# Altium schematic sheet BBB_Connections.SchDoc(BBB_Connections)
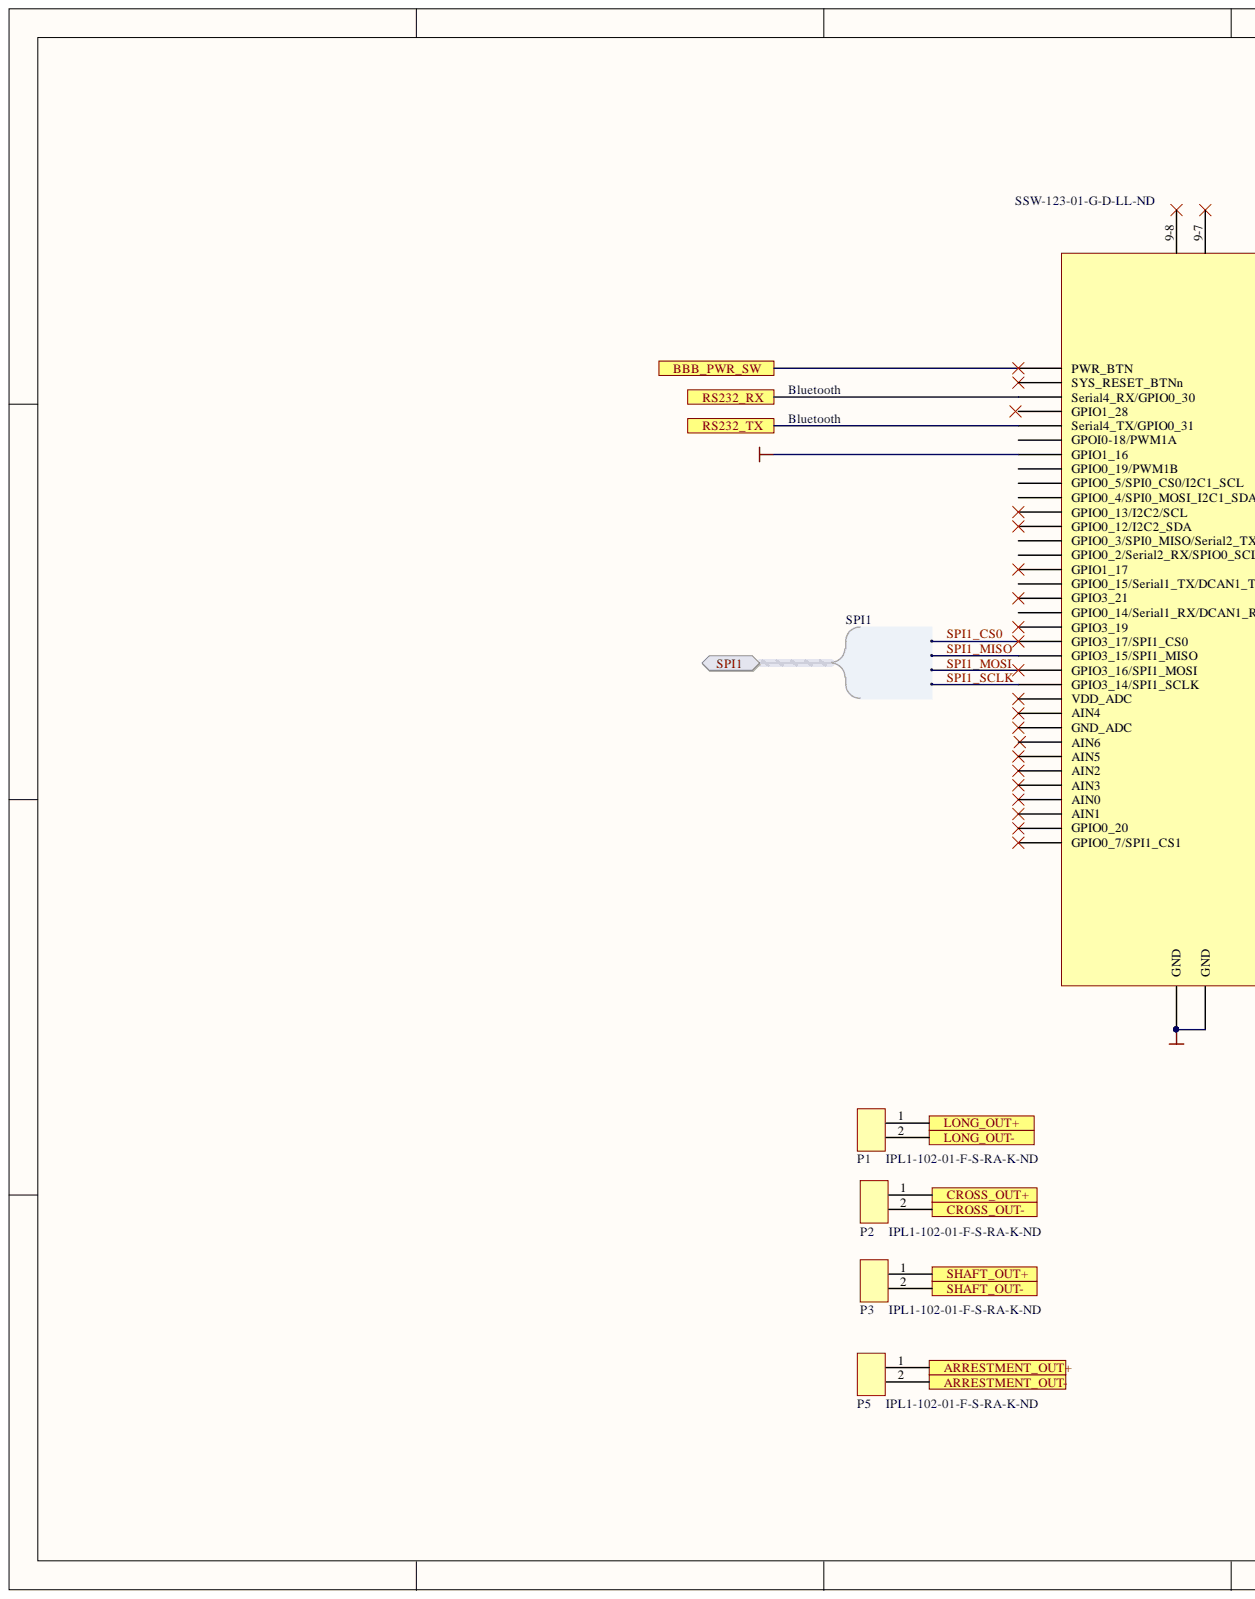
[diagram p0: BBB_Connections.SchDoc, left]
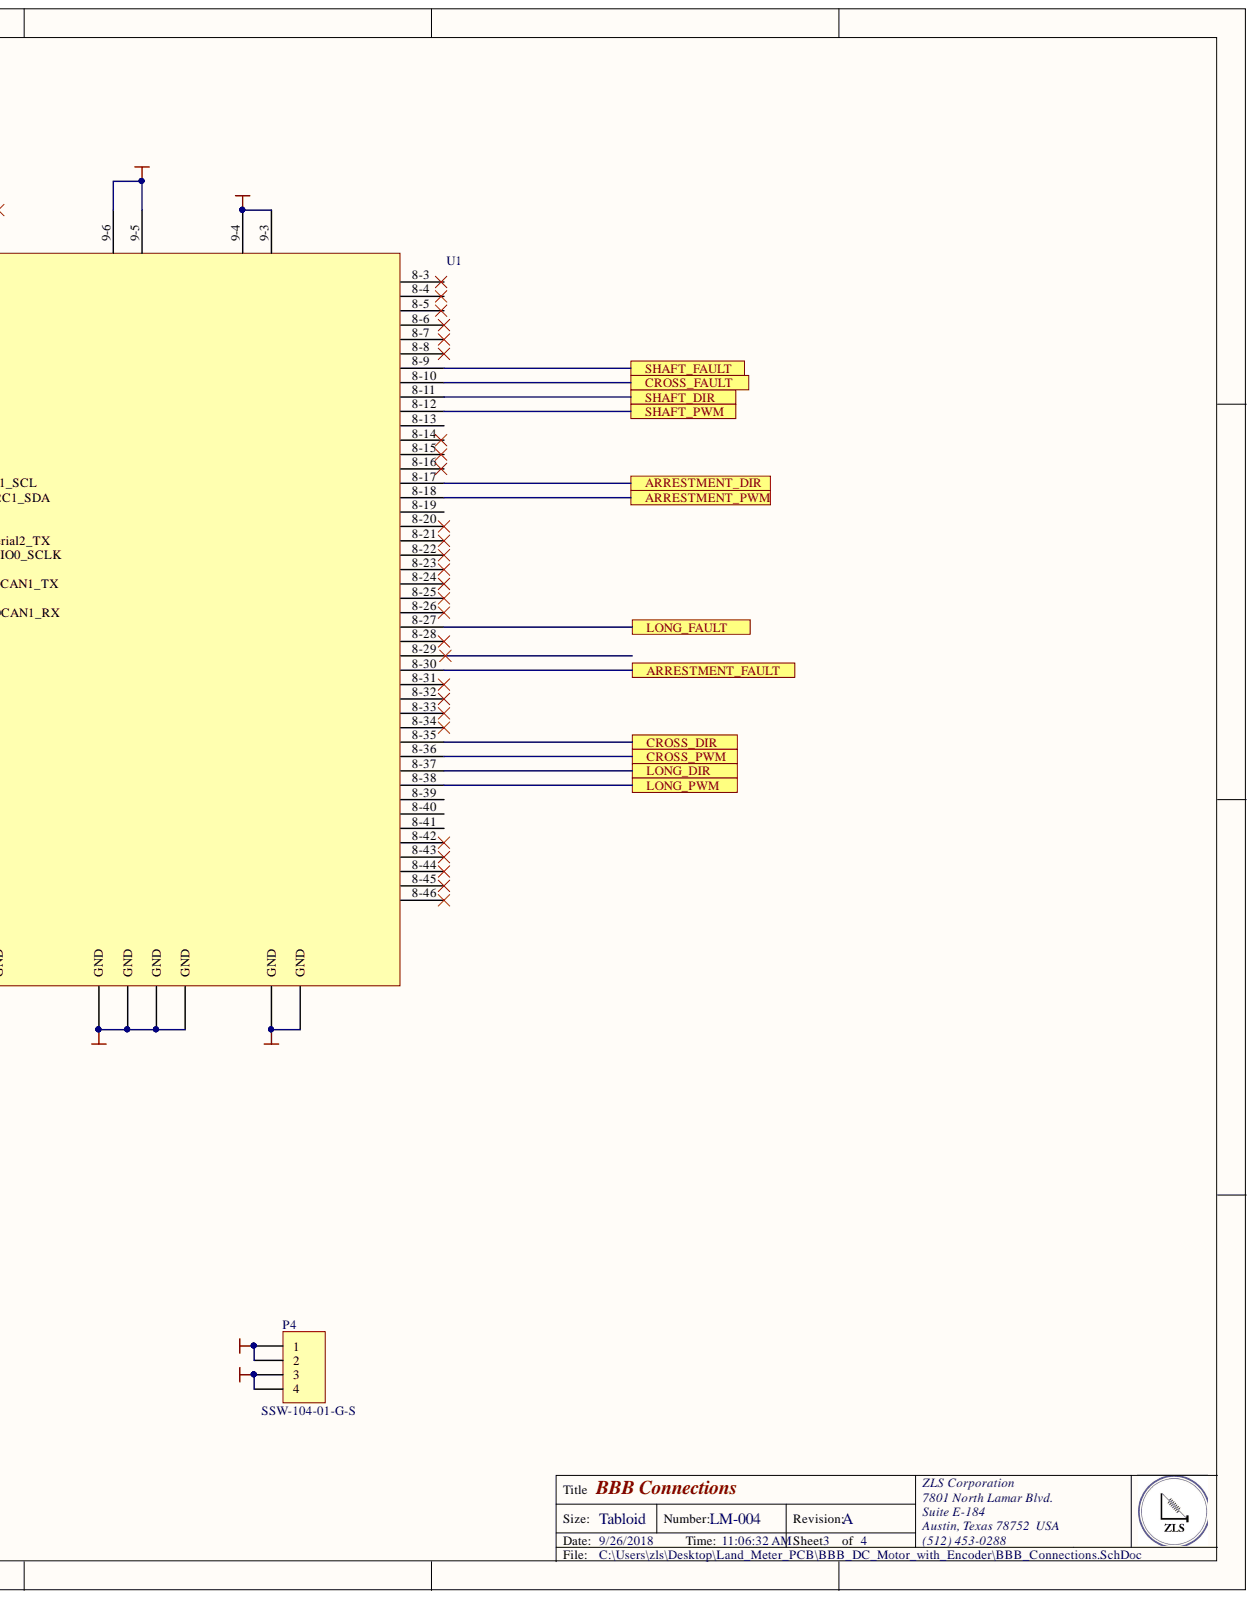
[diagram p1: BBB_Connections.SchDoc, right]

## Parts
P1  IPL1-102-01-F-S-RA-K  Supplier Part Number 1: IPL1-102-01-F-S-RA-K-ND  [IPL1-102-01-F-S-RA-K]
P2  IPL1-102-01-F-S-RA-K  Supplier Part Number 1: IPL1-102-01-F-S-RA-K-ND  [IPL1-102-01-F-S-RA-K]
P3  IPL1-102-01-F-S-RA-K  Supplier Part Number 1: IPL1-102-01-F-S-RA-K-ND  [IPL1-102-01-F-S-RA-K]
P4  SSW-104-01-G-S  [HDR1X4]
P5  IPL1-102-01-F-S-RA-K  Supplier Part Number 1: IPL1-102-01-F-S-RA-K-ND  [IPL1-102-01-F-S-RA-K]
U1  Supplier Part Number 1: SSW-123-01-G-D-LL-ND  [Beaglebone Black Mezanine]  (hidden comment: BBB)

## Ports
ARRESTMENT_DIR, ARRESTMENT_FAULT, ARRESTMENT_OUT+, ARRESTMENT_OUT-, ARRESTMENT_PWM, BBB_PWR_SW, CROSS_DIR, CROSS_FAULT, CROSS_OUT+, CROSS_OUT-, CROSS_PWM, LONG_DIR, LONG_FAULT, LONG_OUT+, LONG_OUT-, LONG_PWM, RS232_RX, RS232_TX, SHAFT_DIR, SHAFT_FAULT, SHAFT_OUT+, SHAFT_OUT-, SHAFT_PWM, SPI1, SPI1.CS_Levels, SPI1.SPI1_MISO, SPI1.SPI1_MOSI, SPI1.SPI1_SCLK

## Nets
+3.3: P4.3 P4.4 U1.9-15(GPIO1_16)
+3.3 BBB: U1.9-3 U1.9-4
+5 BBB: U1.9-5 U1.9-6
+12: P4.1 P4.2
GND: U1.8-1(GND) U1.8-2(GND) U1.9-1(GND) U1.9-2(GND) U1.9-43(GND) U1.9-44(GND) U1.9-45(GND) U1.9-46(GND)
ARRESTMENT_DIR: U1.8-17 ; port ARRESTMENT_DIR
ARRESTMENT_FAULT: U1.8-30 ; port ARRESTMENT_FAULT
ARRESTMENT_PWM: U1.8-18 ; port ARRESTMENT_PWM
BBB_PWR_SW: U1.9-9(PWR_BTN) ; port BBB_PWR_SW
CROSS_DIR: U1.8-35 ; port CROSS_DIR
CROSS_FAULT: U1.8-10 ; port CROSS_FAULT
CROSS_PWM: U1.8-36 ; port CROSS_PWM
LONG_DIR: U1.8-37 ; port LONG_DIR
LONG_FAULT: U1.8-27 ; port LONG_FAULT
LONG_PWM: U1.8-38 ; port LONG_PWM
RS232_RX: U1.9-11(Serial4_RX/GPIO0_30) ; port RS232_RX
RS232_TX: U1.9-13(Serial4_TX/GPIO0_31) ; port RS232_TX
SHAFT_DIR: U1.8-11 ; port SHAFT_DIR
SHAFT_FAULT: U1.8-9 ; port SHAFT_FAULT
SHAFT_PWM: U1.8-12 ; port SHAFT_PWM
SPI1_CS0: U1.9-28(GPIO3_17/SPI1_CS0) ; port SPI1
SPI1_MISO: U1.9-29(GPIO3_15/SPI1_MISO) ; port SPI1
SPI1_MOSI: U1.9-30(GPIO3_16/SPI1_MOSI) ; port SPI1
SPI1_SCLK: U1.9-31(GPIO3_14/SPI1_SCLK) ; port SPI1
NetP1_1: P1.1
NetP1_2: P1.2
NetP2_1: P2.1
NetP2_2: P2.2
NetP3_1: P3.1
NetP3_2: P3.2
NetP5_1: P5.1
NetP5_2: P5.2
N00006:

Not connected: U1 8-3 8-4 8-5 8-6 8-7 8-8 8-13 8-14 8-15 8-16 8-19 8-20 8-21 8-22 8-23 8-24 8-25 8-26 8-28 8-29 8-31 8-32 8-33 8-34 8-39 8-40 8-41 8-42 8-43 8-44 8-45 8-46 9-7 9-8 9-10(SYS_RESET_BTNn) 9-12(GPIO1_28) 9-14(GPOI0-18/PWM1A) 9-16(GPIO0_19/PWM1B) 9-17(GPIO0_5/SPI0_CS0/I2C1_SCL) 9-18(GPIO0_4/SPI0_MOSI_I2C1_SDA) 9-19(GPIO0_13/I2C2/SCL) 9-20(GPIO0_12/I2C2_SDA) 9-21(GPIO0_3/SPI0_MISO/Serial2_TX) 9-22(GPIO0_2/Serial2_RX/SPIO0_SCLK) 9-23(GPIO1_17) 9-24(GPIO0_15/Serial1_TX/DCAN1_TX) 9-25(GPIO3_21) 9-26(GPIO0_14/Serial1_RX/DCAN1_RX) 9-27(GPIO3_19) 9-32(VDD_ADC) 9-33(AIN4) 9-34(GND_ADC) 9-35(AIN6) 9-36(AIN5) 9-37(AIN2) 9-38(AIN3) 9-39(AIN0) 9-40(AIN1) 9-41(GPIO0_20) 9-42(GPIO0_7/SPI1_CS1)

## Library data of the parts
P1-P3 P5 = IPL1-102-01-F-S-RA-K: Manufacturer: Samtec Inc.; Voltage Rating: 675VAC, 954VDC; Style: Board to Cable/Wire; Shrouding: Shrouded - 4 Wall; Pitch - Mating: 0.100" (2.54mm); Number of Positions Loaded: All; Number of Positions: 2; Material Flammability Rating: UL94 V-0; Contact Length - Post: 0.085" (2.16mm); Contact Length - Mating: 0.215" (5.46mm); Connector Type: Header; Termination: Solder; Supplier 1: Digi-Key; Stock 1: 0; Row Spacing - Mating: -; RoHS: RoHS Compliant; Pricing 1: 1=2.09 (USD); Part Status: Active; Packaging: Tube; Overall Contact Length: -; Operating Temperature: -55°C ~ 125°C; Number of Rows: 1; Mounting Type: Through Hole, Right Angle; Mated Stacking Heights: -; Manufacturer Part Number: IPL1-102-01-F-S-RA-K; Insulation Material: Liquid Crystal Polymer (LCP); Insulation Height: 0.240" (6.10mm); Insulation Color: Natural; Ingress Protection: -; Features: -; Fastening Type: Locking Ramp; Current Rating: -; Contact Type: Male Pin; Contact Shape: Square; Contact Material: Phosphor Bronze; Contact Finish Thickness - Post: -; Contact Finish Thickness - Mating: 3.00µin (0.076µm); Contact Finish - Post: Tin; Contact Finish - Mating: Gold; ComponentLink1URL: http://suddendocs.samtec.com/prints/ipl1-1xx-xx-xxx-s-xx-x-xx-mkt.pdf; ComponentLink1Description: http://suddendocs.samtec.com/prints/ipl1-1xx-xx-xxx-s-xx-x-xx-mkt.pdf; Component Kind: Standard; Category: Connectors, Interconnects; Applications: -; Library Name: ZLS_Schematic_lib.SchLib
P4 = Header 4: Header, 4-Pin; Manufacturer: Samtec Inc.; Style: Board to Board or Cable; Pitch - Mating: 0.100" (2.54mm); Number of Positions Loaded: All; Number of Positions: 4; Material Flammability Rating: UL94 V-0; Current Rating: 5.7A per Contact; Contact Length - Post: 0.104" (2.64mm); Connector Type: Receptacle; Voltage Rating: -; Termination: Solder; Supplier Part Number 1: SAM1209-04-ND; Supplier 1: Digi-Key; Stock 1: 360; Signal Integrity: Connector; Row Spacing - Mating: -; RoHS: RoHS Compliant; Pricing 1: 1=1.85, 10=1.654, 100=1.3623, 500=1.07684, 1000=0.9244, 5000=0.84331, 10000=0.81088 (USD); Part Status: Active; Packaging: Bulk; Operating Temperature: -55°C ~ 125°C; Mounting Type: Through Hole; Mated Stacking Heights: -; Manufacturer Part Number: SSW-104-01-G-S; Library Name: Miscellaneous Connectors.IntLib; Insulation Material: Liquid Crystal Polymer (LCP); Insulation Height: 0.335" (8.51mm); Insulation Color: Black; Ingress Protection: -; Features: -; Fastening Type: Push-Pull; Contact Type: Forked; Contact Shape: Square; Contact Material: Phosphor Bronze; Contact Finish Thickness - Post: 3.00µin (0.076µm); Contact Finish Thickness - Mating: 20.0µin (0.51µm); Contact Finish - Post: Gold; Contact Finish - Mating: Gold; ComponentLink1URL: http://suddendocs.samtec.com/prints/ssw-1xx-xx-xxx-x-xx-xxx-xx-mkt.pdf; ComponentLink1Description: http://suddendocs.samtec.com/prints/ssw-1xx-xx-xxx-x-xx-xxx-xx-mkt.pdf; Component Kind: Standard; Category: Connectors, Interconnects; Applications: -
U1 = Beaglebone_Black_Mezanine: Beaglebone Black; Manufacturer Part Number: SSW-123-01-G-D-LL; Manufacturer: Samtec Inc.; Style: Board to Board or Cable; Row Spacing - Mating: 0.100" (2.54mm); Number of Positions Loaded: All; Number of Positions: 46; Material Flammability Rating: UL94 V-0; Current Rating: 5.7A per Contact; Contact Length - Post: 0.104" (2.64mm); Connector Type: Receptacle; Voltage Rating: -; Termination: Kinked Pin, Solder; Supplier 1: Digi-Key; Stock 1: 0; RoHS: RoHS Compliant; Pricing 1: 1=6.07 (USD); Pitch - Mating: 0.100" (2.54mm); Part Status: Active; Packaging: Bulk; Operating Temperature: -55°C ~ 125°C; Mounting Type: Through Hole; Mated Stacking Heights: -; Insulation Material: Liquid Crystal Polymer (LCP); Insulation Height: 0.335" (8.51mm); Insulation Color: Black; Ingress Protection: -; Features: -; Fastening Type: Push-Pull; Contact Type: Forked; Contact Shape: Square; Contact Material: Phosphor Bronze; Contact Finish Thickness - Post: 3.00µin (0.076µm); Contact Finish Thickness - Mating: 20.0µin (0.51µm); Contact Finish - Post: Gold; Contact Finish - Mating: Gold; Component Kind: Standard; Category: Connectors, Interconnects; Applications: -; Library Name: ZLS_Schematic_lib.SchLib
